# Altium schematic sheet IMX6ULL_MINI_POWER.SchDoc(IMX6ULL_MINI_POWER)
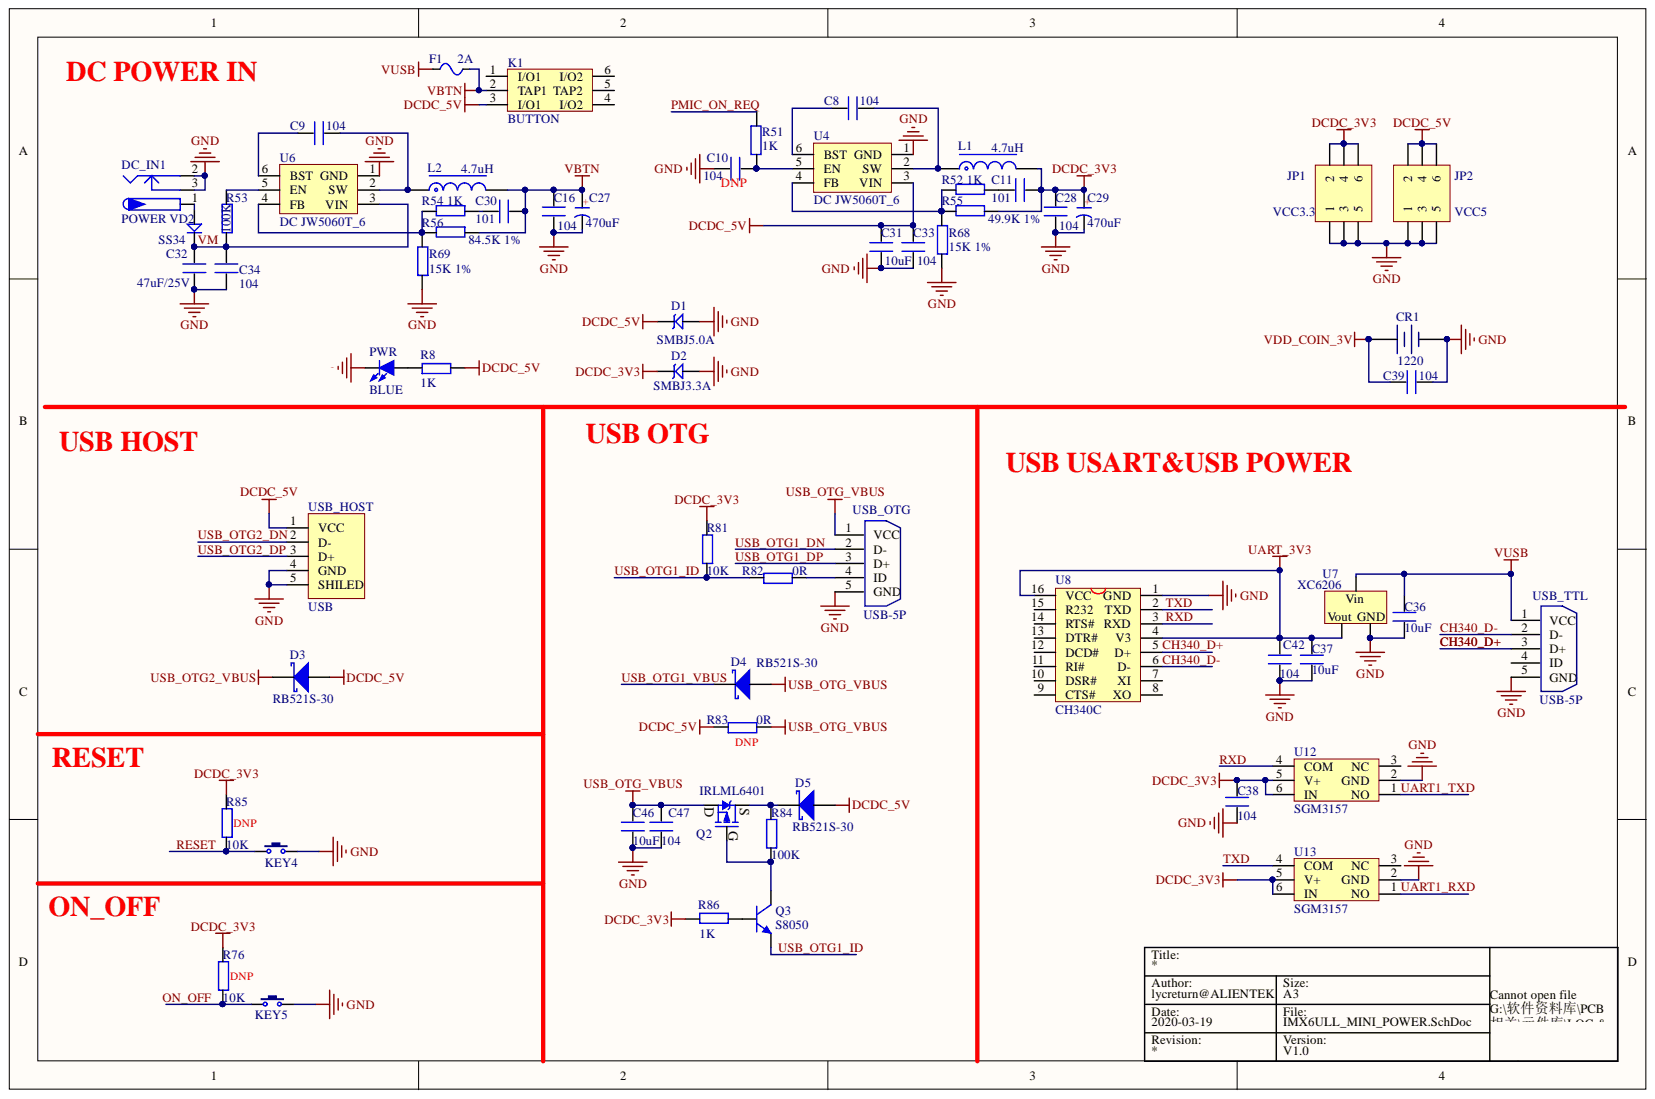
[diagram p0: IMX6ULL_MINI_POWER.SchDoc]

## Parts
C8  104  [C 0603]
C9  104  [C 0603]
C10  104  [C 0603]
C11  101  [C 0603]
C16  104  [C 0603]
C27  470uF  [CM D(6.3*7.7)]
C28  104  [C 0603]
C29  470uF  [CM D(6.3*7.7)]
C30  101  [C 0603]
C31  10uF  [0805]
C32  47uF/25V  [C 1206]
C33  104  [C 0603]
C34  104  [C 0603]
C36  10uF  [0805]
C37  10uF  [0805]
C38  104  [C 0603]
C39  104  [C 0603]
C42  104  [C 0603]
C46  10uF  [0805]
C47  104  [C 0603]
CR1  1220  [CR1220_B]
D1  SMBJ5.0A  [SMBJ]
D2  SMBJ3.3A  [SMBJ]
D3  RB521S-30  [SOD-523]
D4  RB521S-30  [SOD-523]
D5  RB521S-30  [SOD-523]
DC_IN1  POWER  [DC10B]
F1  2A  [FU 1206]
JP1  VCC3.3  [HDR-3X2]
JP2  VCC5  [HDR-3X2]
K1  BUTTON  [BTN8.5*8.5]
KEY4  KEY  [TSW DIP-6*6*5 - 2]
KEY5  KEY  [TSW DIP-6*6*5 - 2]
L1  4.7uH  [L-SMD-0420]
L2  4.7uH  [L-SMD-0420]
PWR  BLUE  [LED 0805B]
Q2  IRLML6401  [SOT23-3L]
Q3  S8050  [SOT23-3L]
R8  1K  [R 0603]
R51  1K  [R 0603]
R52  1K  [R 0603]
R53  100K  [R 0603]
R54  1K  [R 0603]
R55  49.9K 1%  [R 0603]
R56  84.5K 1%  [R 0603]
R68  15K 1%  [R 0603]
R69  15K 1%  [R 0603]
R76  10K  [R 0603]
R81  10K  [R 0603]
R82  0R  [R 0603]
R83  0R  [R 0603]
R84  100K  [R 0603]
R85  10K  [R 0603]
R86  1K  [R 0603]
U4  DC JW5060T_6  [SOT23-6N]
U6  DC JW5060T_6  [SOT23-6N]
U7  XC6206  [SOT23-3N]
U8  CH340C  [SOP16_L]
U12  SGM3157  [SOT363-6L]
U13  SGM3157  [SOT363-6L]
USB_HOST  USB  [USB-A/S_E]
USB_OTG  USB-5P  [USB-MINI-A]
USB_TTL  USB-5P  [USB-MINI-A]
VD2  SS34  [SMA]

## Nets
DCDC_3V3: C11.2 C28.1 C29.1 C38.2 D2.2 JP1.2 JP1.4 JP1.6 L1.2 R55.1 R76.2 R81.2 R85.2 R86.2 U12.5(V+) U12.6(IN) U13.5(V+) U13.6(IN)
DCDC_5V: C31.1 C33.1 D1.2 D3.1 D5.1 JP2.2 JP2.4 JP2.6 K1.3(I/O1) R8.2 R83.1 U4.3(VIN) USB_HOST.1(VCC)
GND: C10.2 C16.2 C27.2 C28.2 C29.2 C31.2 C32.1 C33.2 C34.2 C36.2 C37.2 C38.1 C39.1 C42.2 C46.2 C47.2 CR1.2 D1.1 D2.1 DC_IN1.2 DC_IN1.3 JP1.1 JP1.3 JP1.5 JP2.1 JP2.3 JP2.5 KEY4.2 KEY5.2 PWR.2 R68.1 R69.1 U4.1(GND) U6.1(GND) U7.1 U8.1(GND) U12.2(GND) U13.2(GND) USB_HOST.4(GND) USB_HOST.5(SHILED) USB_OTG.5(GND) USB_TTL.5(GND)
UART_3V3: C37.1 C42.1 U7.2 U8.4(V3) U8.16(VCC)
USB_OTG2_VBUS: D3.2
USB_OTG_VBUS: C46.1 C47.1 D4.1 Q2.3 R83.2 USB_OTG.1(VCC)
VBTN: C16.1 C27.1 C30.2 F1.2 K1.2(TAP1) L2.2 R56.1
VDD_COIN_3V: C39.2 CR1.1
VUSB: C36.1 F1.1 U7.3 USB_TTL.1(VCC)
CH340_D+: U8.5(D+) USB_TTL.3(D+)
CH340_D-: U8.6(D-) USB_TTL.2(D-)
PMIC_ON_REQ: R51.1
RESET: KEY4.1 R85.1
RXD: U8.3(RXD) U12.4(COM)
TXD: U8.2(TXD) U13.4(COM)
UART1_RXD: U13.1(NO)
UART1_TXD: U12.1(NO)
USB_OTG1_DN: USB_OTG.2(D-)
USB_OTG1_DP: USB_OTG.3(D+)
USB_OTG1_ID: Q3.2 R81.1 R82.1
USB_OTG1_VBUS: D4.2
USB_OTG2_DN: USB_HOST.2(D-)
USB_OTG2_DP: USB_HOST.3(D+)
VM: C32.2 C34.1 R53.1 U6.3(VIN) VD2.2
NetC8_1: C8.1 L1.1 U4.2(SW)
NetC8_2: C8.2 U4.6(BST)
NetC9_1: C9.1 L2.1 U6.2(SW)
NetC9_2: C9.2 U6.6(BST)
NetC10_1: C10.1 R51.2 U4.5(EN)
NetC11_1: C11.1 R52.1
NetC30_1: C30.1 R54.1
NetD5_2: D5.2 Q2.2 R84.2
NetDC_IN1_1: DC_IN1.1 VD2.1
NetKEY5_1: KEY5.1 R76.1
NetPWR_1: PWR.1 R8.1
NetQ2_1: Q2.1 Q3.3 R84.1
NetQ3_1: Q3.1 R86.1
NetR52_2: R52.2 R55.2 R68.2 U4.4(FB)
NetR53_2: R53.2 U6.5(EN)
NetR54_2: R54.2 R56.2 R69.2 U6.4(FB)
NetR82_2: R82.2 USB_OTG.4(ID)
ON_OFF:

Not connected: K1 1(I/O1) 4(I/O2) 5(TAP2) 6(I/O2); U8 7(XI) 8(XO) 9(CTS#) 10(DSR#) 11(RI#) 12(DCD#) 13(DTR#) 14(RTS#) 15(R232); U12 3(NC); U13 3(NC); USB_TTL 4(ID)

## Library data of the parts
C8-C11 C16 C28 C30-C34 C36-C39 C42 C46 C47 = C: Component Kind: Standard; Library Name: 电阻-电容-电感.SchLib
C27 C29 = C-CM: 贴片电解电容; 版权归属: 源创客; BOM名称: 贴片电解电容; Component Kind: Standard; Library Name: IMX6UL_ALPHA_V2.0.IntLib; 学习资源: www.ooocke.com
CR1 = CR-3V: 微型电池; 版权归属: 源创客; BOM名称: 微型电池; Component Kind: Standard; Library Name: 未分类器件.SchLib; 学习资源: www.ooocke.com
D1 D2 = SMBJ: TVS; Component Kind: Standard; Library Name: 阿波罗STM32F4&F7底板封装库.IntLib
DC_IN1 = P-DC: 低压电源接口; BOM名称: DC电源接口; 版权归属: 源创客; Component Kind: Standard; Library Name: 接口类.SchLib; 学习资源: www.ooocke.com
F1 = FU: 贴片保险丝; 版权归属: 源创客; BOM名称: 贴片保险丝; Component Kind: Standard; Library Name: 保险丝-晶振.SchLib; 学习资源: www.ooocke.com
JP1 JP2 = Header 3X2: 3*2P接插件; 版权归属: 源创客; BOM名称: 3*2P接插件; Component Kind: Standard; Library Name: 接插件.SchLib; 学习资源: www.ooocke.com
KEY4 KEY5 = KEY: 按键; BOM名称: 3x6轻触开关; 版权归属: 源创客; Component Kind: Standard; Library Name: 按键-开关.SchLib; 学习资源: www.ooocke.com
L1 L2 = L-CDRH: 功率屏蔽电感; 版权归属: 源创客; BOM名称: 功率屏蔽电感; Component Kind: Standard; Library Name: IMX6UL_ALPHA_V2.0.IntLib; 学习资源: www.ooocke.com
PWR = LED-SMD: 贴片LED; 版权归属: 源创客; BOM名称: 贴片LED; Component Kind: Standard; Library Name: LED.SchLib; 学习资源: www.ooocke.com
Q2 = SI2301: P沟道场效应管; 版权归属: 源创客; BOM名称: P沟道场效应管; Component Kind: Standard; Library Name: 场效应管-可控硅.SchLib; 学习资源: www.ooocke.com
Q3 = 8050-SMD: 高频放大-NPN型; 属性: 40V 1.5A 1W 100MHZ; 版权归属: 源创客; BOM名称: 高频放大-NPN型; Component Kind: Standard; Library Name: 三极管.SchLib; 学习资源: www.ooocke.com
R8 R51-R56 R68 R69 R76 R81-R86 = R: Component Kind: Standard; Library Name: 电阻-电容-电感.SchLib
U4 U6 = DC JW5060T_6: DC降压芯片; Component Kind: Standard; Library Name: IMX6UL_ALPHA_V2.0.IntLib
U7 = LDO XC6206: LDO; Component Kind: Standard; ComponentLink1Description: 供应商链接; ComponentLink1URL: http://www.szlcsc.com/product/details_5896.html; Library Name: IMX6UL_ALPHA_V2.0.IntLib; Package: SOT-23; Supplier: LC; SuppliersPartNumber: C5446
U8 = CH340G: USB2UART; Component Kind: Standard; Library Name: ALIENTEK_COMPONENT.IntLib
U12 U13 = SGM3157: 单路双掷模拟开关; Component Kind: Standard; Library Name: IMX6UL_ALPHA_V2.0.IntLib
USB_HOST = USB-A/S: USB-A型母接口; 版权归属: 源创客; BOM名称: USB-A型母接口; Component Kind: Standard; Library Name: 接口类.SchLib; 学习资源: www.ooocke.com
USB_OTG USB_TTL = USB-5P: 微型USB母座; 版权归属: 源创客; BOM名称: 微型USB母座; Component Kind: Standard; Library Name: 接口类.SchLib; 学习资源: www.ooocke.com
VD2 = SS14: 肖特基二极管; 属性: 40V/1A; 版权归属: 源创客; BOM名称: 肖特基二极管; Component Kind: Standard; Library Name: 二极管-整流桥.SchLib; 学习资源: www.ooocke.com
D3-D5 = RB521S-30: Component Kind: Standard; Library Name: ZZK_COMPONENT.IntLib
K1 = BUTTON: Component Kind: Standard; Library Name: 阿波罗STM32F4&F7底板封装库.IntLib
